# Altium schematic sheet DEVICE.SchDoc(DEVICE)
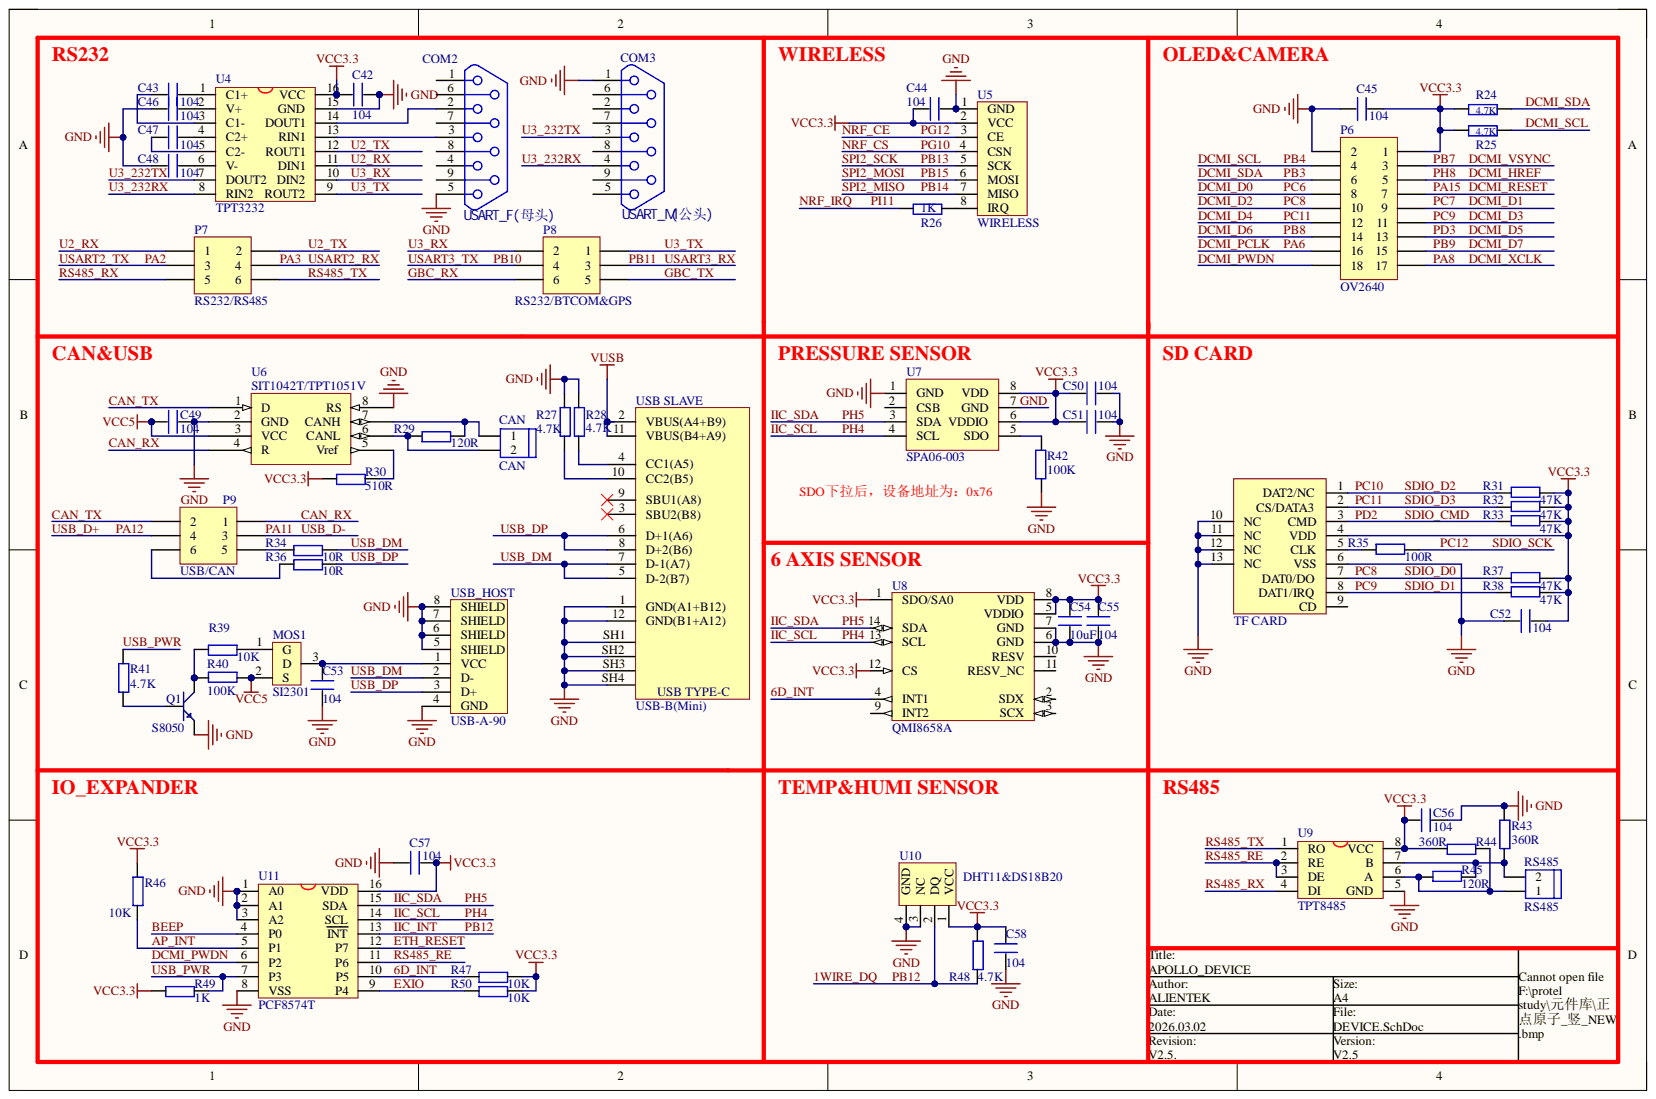
[diagram p0: DEVICE.SchDoc]

## Parts
C42  104  [0402]
C43  104  [0402]
C44  104  [0402]
C45  104  [0402]
C46  104  [0402]
C47  104  [0402]
C48  104  [0402]
C49  104  [0402]
C50  104  [C 0402]
C51  104  [C 0402]
C52  104  [0402]
C53  104  [0402]
C54  10uF  [0603P]
C55  104  [C 0402]
C56  104  [0402]
C57  104  [0402]
C58  104  [0402]
CAN  CAN  [HEAD2L-0.8]
COM2  USART_F(母头)  [DB9]
COM3  USART_M(公头)  [DB9]
MOS1  SI2301  [SO-G3/C2.5]
P6  OV2640  [HDR2X9]
P7  RS232/RS485  [HDR2X3]
P8  RS232/BTCOM&GPS  [HDR2X3]
P9  USB/CAN  [HDR2X3]
Q1  S8050  [SOT-23R]
R24  4.7K  [0402]
R25  4.7K  [0402]
R26  1K  [0402]
R27  4.7K  [0402]
R28  4.7K  [0402]
R29  120R  [0402]
R30  510R  [0402]
R31  47K  [0402]
R32  47K  [0402]
R33  47K  [0402]
R34  10R  [0402]
R35  100R  [0402]
R36  10R  [0402]
R37  47K  [0402]
R38  47K  [0402]
R39  10K  [0402]
R40  100K  [0402]
R41  4.7K  [0402]
R42  100K  [0402]
R43  360R  [0402]
R44  360R  [0402]
R45  120R  [0402]
R46  10K  [0402]
R47  10K  [0402]
R48  4.7K  [0402]
R49  1K  [0402]
R50  10K  [0402]
RS485  RS485  [HEAD2L-0.8]
TF_CARD  SD-MICRO-TF  [SD-MICRO-TF]  (value not drawn)
U4  TPT3232  [SOP16M]
U5  WIRELESS  [NRF24L01]
U6  SIT1042T/TPT1051V  [SOP-8]
U7  SPA06-003  [LGA-8(2x2.5)]
U8  QMI8658A  [LGA14]
U9  TPT8485  [SOP-8]
U10  DHT11&DS18B20  [DHT11]
U11  PCF8574T  [SO-16]
USB_SLAVE  USB-B(Mini)  [USB Type-C]
USB_HOST  USB-A-90  [USB-A-90]

## Nets
VCC3.3: C42.1 C44.2 C45.1 C50.2 C51.2 C52.2 C54.2 C55.2 C56.2 C57.1 C58.1 P6.1 R24.1 R25.1 R30.1 R31.1 R32.1 R33.1 R37.1 R38.1 R44.1 R46.2 R47.2 R48.2 R49.1 R50.2 TF_CARD.4(VDD) U4.16(VCC) U5.2(VCC) U7.6(VDDIO) U7.8(VDD) U8.1(SDO/SA0) U8.5(VDDIO) U8.8(VDD) U8.12(CS) U9.8(VCC) U10.1(VCC) U11.16(VDD)
VCC5: C49.1 MOS1.2 R40.1 U6.3(VCC)
VUSB: USB_SLAVE.2(VBUS(A4+B9)) USB_SLAVE.11(VBUS(B4+A9))
1WIRE_DQ: R48.1 U10.2(DQ) U11.13(INT)
6D_INT: R47.1 U8.4(INT1) U11.10(P5)
AP_INT: R46.1 U11.5(P1)
BEEP: U11.4(P0)
CAN_RX: P9.1 U6.4(R)
CAN_TX: P9.2 U6.1(D)
DCMI_D0: P6.8
DCMI_D1: P6.9
DCMI_D2: P6.10 R37.2 TF_CARD.7(DAT0/DO)
DCMI_D3: P6.11 R38.2 TF_CARD.8(DAT1/IRQ)
DCMI_D4: P6.12 R32.2 TF_CARD.2(CS/DATA3)
DCMI_D5: P6.13
DCMI_D6: P6.14
DCMI_D7: P6.15
DCMI_HREF: P6.5
DCMI_PCLK: P6.16
DCMI_PWDN: P6.18 U11.6(P2)
DCMI_RESET: P6.7
DCMI_SCL: P6.4 R25.2
DCMI_SDA: P6.6 R24.2
DCMI_VSYNC: P6.3
DCMI_XCLK: P6.17
ETH_RESET: U11.12(P7)
EXIO: R50.1 U11.9(P4)
GBC_RX: P8.6
GBC_TX: P8.5
GND: C42.2 C44.1 C45.2 C46.2 C48.1 C49.2 C50.1 C51.1 C52.1 C53.1 C54.1 C55.1 C56.1 C57.2 C58.2 COM2.5 COM3.1 P6.2 Q1.1 R27.2 R28.2 R42.2 R43.2 TF_CARD.6(VSS) TF_CARD.10(NC) TF_CARD.11(NC) TF_CARD.12(NC) TF_CARD.13(NC) U4.15(GND) U5.1(GND) U6.2(GND) U6.8(RS) U7.1(GND) U7.7(GND) U8.6(GND) U8.7(GND) U9.5(GND) U10.3(NC) U10.4(GND) U11.1(A0) U11.2(A1) U11.3(A2) U11.8(VSS) USB_SLAVE.1(GND(A1+B12)) USB_SLAVE.12(GND(B1+A12)) USB_SLAVE.SH1(Shield1) USB_SLAVE.SH2(Shield2) USB_SLAVE.SH3(Shield3) USB_SLAVE.SH4(Shield4) USB_HOST.4(GND) USB_HOST.5(SHIELD) USB_HOST.6(SHIELD) USB_HOST.7(SHIELD) USB_HOST.8(SHIELD)
IIC_SCL: U7.4(SCL) U8.13(SCL) U11.14(SCL)
IIC_SDA: U7.3(SDA) U8.14(SDA) U11.15(SDA)
NRF_CE: U5.3(CE)
NRF_CS: U5.4(CSN)
NRF_IRQ: R26.1
PA2: P7.3
PA3: P7.4
PA11: P9.3
PA12: P9.4
PB10: P8.4
PB11: P8.3
PB13: U5.5(SCK)
PB14: U5.7(MISO)
PB15: U5.6(MOSI)
PC10: R31.2 TF_CARD.1(DAT2/NC)
PC12: R35.1
PD2: R33.2 TF_CARD.3(CMD)
RS485_RE: U9.2(RE) U9.3(DE) U11.11(P6)
RS485_RX: P7.5 U9.4(DI)
RS485_TX: P7.6 U9.1(RO)
U2_RX: P7.1 U4.11(DIN1)
U2_TX: P7.2 U4.12(ROUT1)
U3_232RX: COM3.4 U4.8(RIN2)
U3_232TX: COM3.3 U4.7(DOUT2)
U3_RX: P8.2 U4.10(DIN2)
U3_TX: P8.1 U4.9(ROUT2)
USB_DM: R34.2 USB_SLAVE.5(D-2(B7)) USB_SLAVE.7(D-1(A7)) USB_HOST.2(D-)
USB_DP: R36.2 USB_SLAVE.6(D+1(A6)) USB_SLAVE.8(D+2(B6)) USB_HOST.3(D+)
USB_PWR: R41.2 R49.2 U11.7(P3)
NetC43_1: C43.1 U4.1(C1+)
NetC43_2: C43.2 U4.3(C1-)
NetC46_1: C46.1 U4.2(V+)
NetC47_1: C47.1 U4.5(C2-)
NetC47_2: C47.2 U4.4(C2+)
NetC48_2: C48.2 U4.6(V-)
NetC53_2: C53.2 MOS1.3 USB_HOST.1(VCC)
NetCAN_1: CAN.1 R29.2 U6.7(CANH)
NetCAN_2: CAN.2 R29.1 U6.6(CANL)
NetCOM2_2: COM2.2 U4.14(DOUT1)
NetCOM2_3: COM2.3 U4.13(RIN1)
NetMOS1_1: MOS1.1 R39.2
NetP9_5: P9.5 R34.1
NetP9_6: P9.6 R36.1
NetQ1_2: Q1.2 R41.1
NetQ1_3: Q1.3 R39.1 R40.2
NetR26_2: R26.2 U5.8(IRQ)
NetR27_1: R27.1 USB_SLAVE.10(CC2(B5))
NetR28_1: R28.1 USB_SLAVE.4(CC1(A5))
NetR30_2: R30.2 U6.5(Vref)
NetR35_2: R35.2 TF_CARD.5(CLK)
NetR42_1: R42.1 U7.5(SDO)
NetR43_1: R43.1 R45.1 RS485.2 U9.7(B)
NetR44_2: R44.2 R45.2 RS485.1 U9.6(A)

Not connected: COM2 1 4 6 7 8 9; COM3 2 5 6 7 8 9; TF_CARD 9(CD); U7 2(CSB); U8 2(SDX) 3(SCX) 9(INT2) 10(RESV) 11(RESV_NC); USB_SLAVE 3(SBU2(B8)) 9(SBU1(A8))

## Library data of the parts
C42-C58 = C: Component Kind: Standard; Library Name: MYCOMLIB.IntLib
CAN RS485 = HEAD2: Component Kind: Standard; Library Name: ALIENTEK_COMPONENT.IntLib
COM2 COM3 = DB9: Component Kind: Standard; Library Name: MYCOMLIB.IntLib
MOS1 = MOS-P: IRLML6401/SI2301; Component Kind: Standard; Library Name: ALIENTEK_COMPONENT.IntLib
P6 = Header 9X2: Header, 9-Pin, Dual row; Component Kind: Standard; LatestRevisionDate: 17-Jul-2002; LatestRevisionNote: Re-released for DXP Platform.; Library Name: Miscellaneous Connectors.IntLib; Publisher: Altium Limited
P7-P9 = Header 3X2: Header, 3-Pin, Dual row; Component Kind: Standard; LatestRevisionDate: 17-Jul-2002; LatestRevisionNote: Re-released for DXP Platform.; Library Name: Miscellaneous Connectors.IntLib; Publisher: Altium Limited
Q1 = NPN: 8050/BCW846/BCW847; Component Kind: Standard; Library Name: ALIENTEK_COMPONENT.IntLib
R24-R50 = R: Component Kind: Standard
  R24-R48 R50: Library Name: MYCOMLIB.IntLib
  R49: Library Name: ALIENTEK_COMPONENT.IntLib
TF_CARD = SD-MICRO-TF: SD,MICRO,TF; Component Kind: Standard; Library Name: ALIENTEK_COMPONENT.IntLib
U4 = MAX3232: Component Kind: Standard; Library Name: MYCOMLIB.IntLib
U5 = NRF24L01: Component Kind: Standard; Library Name: MYCOMLIB.IntLib
U6 = SN65HVD230D: Component Kind: Standard
U7 = SPL06-001: 气压计; Component Kind: Standard; Library Name: ATK-MB017.SCHLIB
U8 = QMI8658A: 六轴传感器; Component Kind: Standard; Library Name: ATK-DNH7RX_SCH_V1.0.lib
U9 = MAX3485: Component Kind: Standard; Library Name: MYCOMLIB.IntLib
U10 = DHT11: 数字温湿度传感器; Component Kind: Standard; Library Name: ALIENTEK_COMPONENT.IntLib
U11 = PCF8574T: 8路IO扩展,IIC接口; Component Kind: Standard; Library Name: ALIENTEK_COMPONENT.IntLib
USB_SLAVE = USB Type-C: 12P TypeC 母座; Component Kind: Standard; Library Name: 接口类.SchLib
USB_HOST = USB_A_90: Component Kind: Standard; Description: USB-A-90; Library Name: ALIENTEK_COMPONENT.IntLib
